# Altium schematic sheet USB.SchDoc(USB)
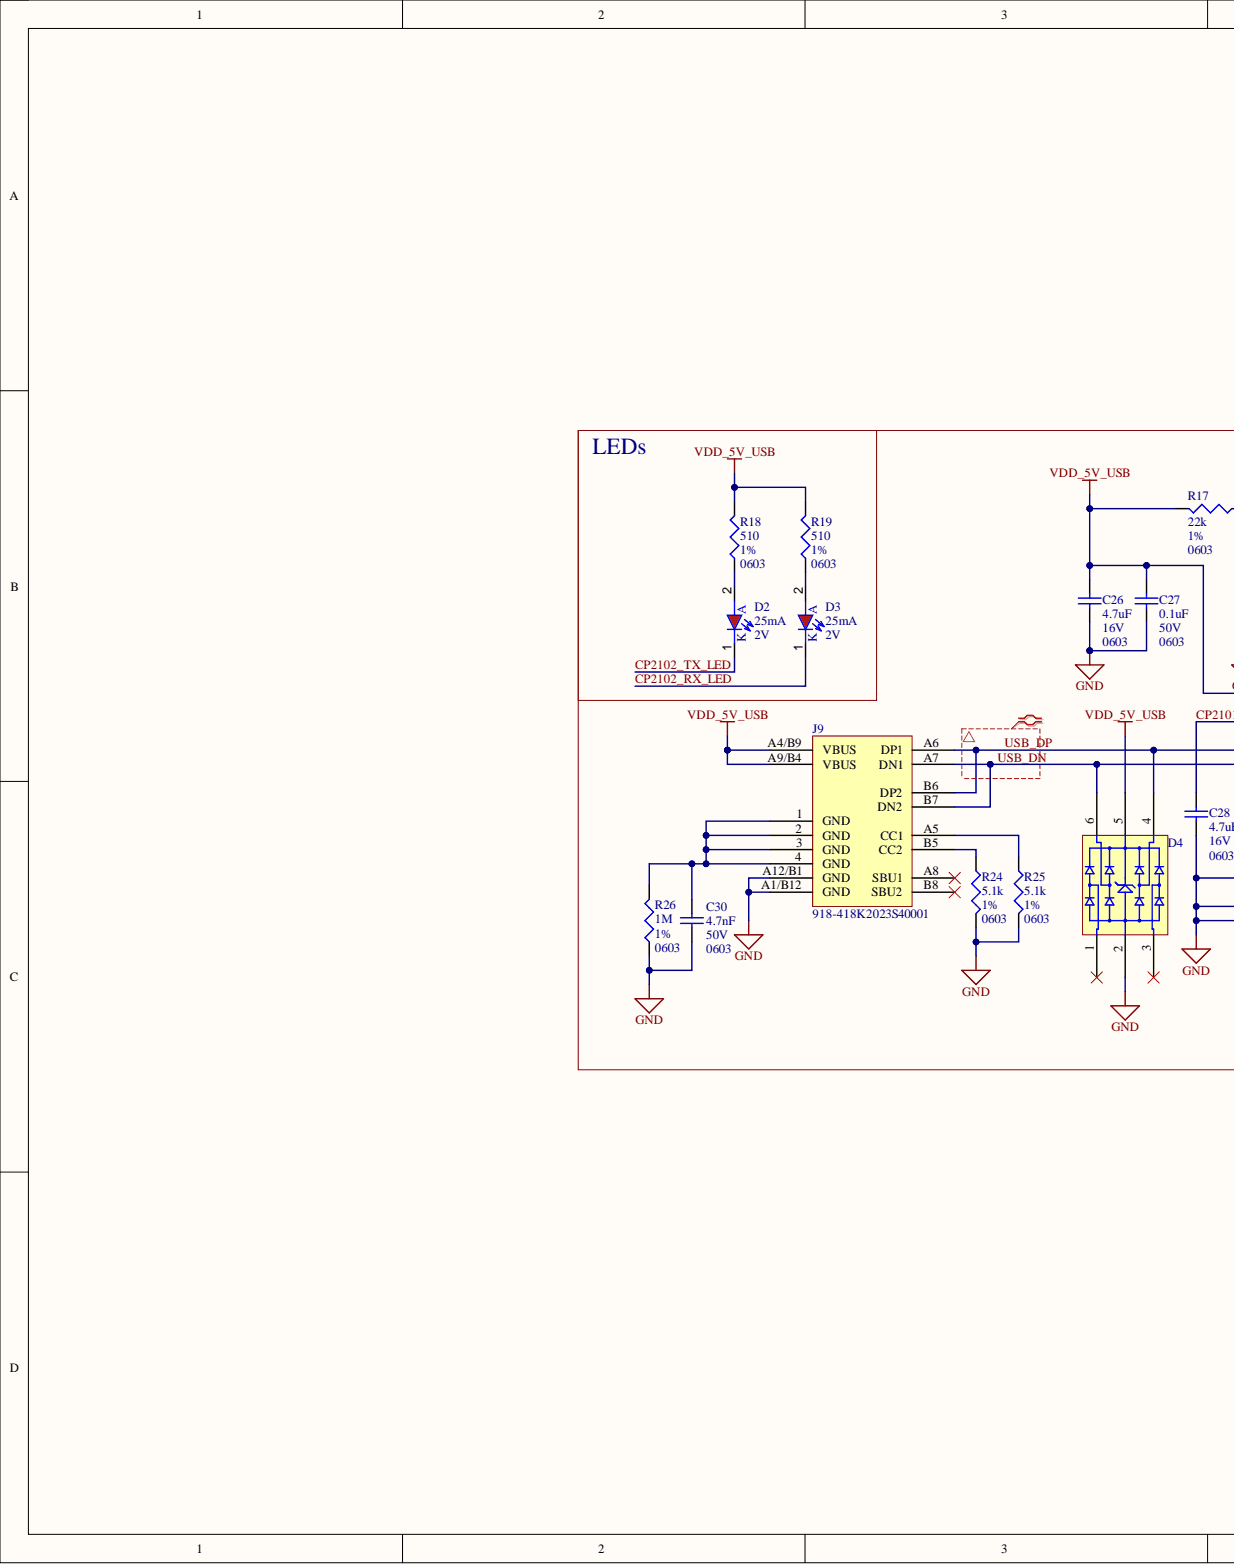
[diagram p0: USB.SchDoc, left]
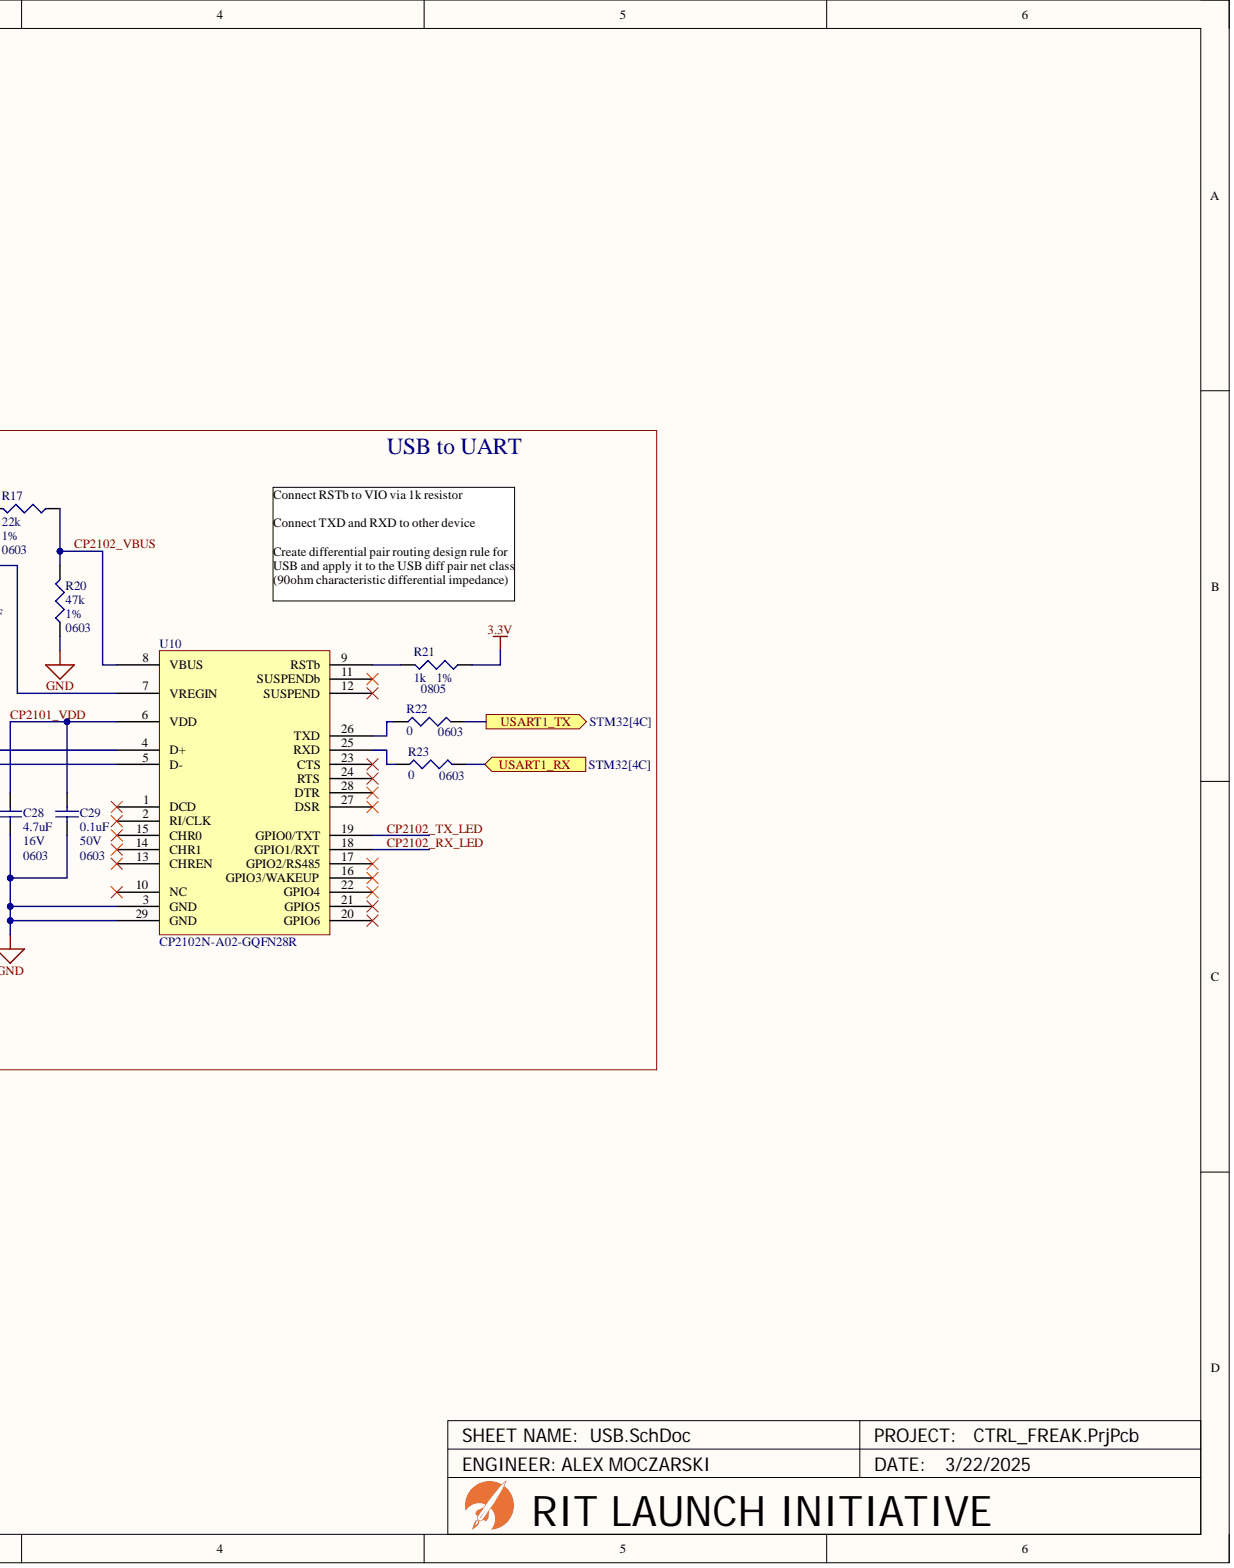
[diagram p1: USB.SchDoc, right]

## Parts
C26  Capacitance: 4.7uF  Case Code (Imperial): 0603  Voltage: 16V  (hidden comment: CL10A475KO8NNNC)
C27  Capacitance: 0.1uF  Case Code (Imperial): 0603  Voltage: 50V  (hidden comment: CC0603KRX7R9BB104)
C28  Capacitance: 4.7uF  Case Code (Imperial): 0603  Voltage: 16V  (hidden comment: CL10A475KO8NNNC)
C29  Capacitance: 0.1uF  Case Code (Imperial): 0603  Voltage: 50V  (hidden comment: CC0603KRX7R9BB104)
C30  Capacitance: 4.7nF  Case/Package: 0603  Voltage: 50V  (hidden comment: 0603B472K500NT)
D2  Forward Voltage: 2V  Max Current: 25mA  (hidden comment: NCD0805R1)
D3  Forward Voltage: 2V  Max Current: 25mA  (hidden comment: NCD0805R1)
D4  SRV05-4-P-T7  (value not drawn)
J9  918-418K2023S40001
R17  Case/Package: 0603  Resistance: 22k  Tolerance: 1%  (hidden comment: 0603WAF2202T5E)
R18  Case/Package: 0603  Tolerance: 1%  (hidden comment: 0603WAF5100T5E)
R19  Case/Package: 0603  Tolerance: 1%  (hidden comment: 0603WAF5100T5E)
R20  Case/Package: 0603  Resistance: 47k  Tolerance: 1%  (hidden comment: 0603WAF4702T5E)
R21  Resistance: 1k  Tolerance: 1%  (hidden comment: 0805W8F1001T5E)
R22  Case/Package: 0603  (hidden comment: ERJ-3GEY0R00V)
R23  Case/Package: 0603  (hidden comment: ERJ-3GEY0R00V)
R24  Case/Package: 0603  Resistance: 5.1k  Tolerance: 1%  (hidden comment: 0603WAF5101T5E)
R25  Case/Package: 0603  Resistance: 5.1k  Tolerance: 1%  (hidden comment: 0603WAF5101T5E)
R26  Case/Package: 0603  Resistance: 1M  Tolerance: 1%  (hidden comment: 0603WAF1004T5E)
U10  CP2102N-A02-GQFN28R

## Ports
USART1_RX, USART1_TX

## Nets
3.3V: R21.1
GND: C26.1 C27.1 C28.1 C29.1 C30.1 D4.2 J9.A1/B12(GND) J9.A12/B1(GND) R20.1 R24.2 R25.2 R26.1 U10.3(GND) U10.29(GND)
VDD_5V_USB: C26.2 C27.2 D4.5 J9.A4/B9(VBUS) J9.A9/B4(VBUS) R17.2 R18.2 R19.2 U10.7(VREGIN)
CP2101_VDD: C28.2 C29.2 U10.6(VDD)
CP2102_RX_LED: D3.1 U10.18(GPIO1/RXT)
CP2102_TX_LED: D2.1 U10.19(GPIO0/TXT)
CP2102_VBUS: R17.1 R20.2 U10.8(VBUS)
USART1_RX: R23.2 ; port USART1_RX
USART1_TX: R22.2 ; port USART1_TX
USB_DN: D4.6 J9.A7(DN1) J9.B7(DN2) U10.5(D-)
USB_DP: D4.4 J9.A6(DP1) J9.B6(DP2) U10.4(D+)
NetC30_2: C30.2 J9.1(GND) J9.2(GND) J9.3(GND) J9.4(GND) R26.2
NetD2_2: D2.2 R18.1
NetD3_2: D3.2 R19.1
NetJ9_A5: J9.A5(CC1) R25.1
NetJ9_B5: J9.B5(CC2) R24.1
NetR21_2: R21.2 U10.9(RSTb)
NetR22_1: R22.1 U10.26(TXD)
NetR23_1: R23.1 U10.25(RXD)

Not connected: D4 1 3; J9 A8(SBU1) B8(SBU2); U10 1(DCD) 2(RI/CLK) 10(NC) 11(SUSPENDb) 12(SUSPEND) 13(CHREN) 14(CHR1) 15(CHR0) 16(GPIO3/WAKEUP) 17(GPIO2/RS485) 20(GPIO6) 21(GPIO5) 22(GPIO4) 23(CTS) 24(RTS) 27(DSR) 28(DTR)

## Library data of the parts
C26 C28 = CMP-001-0241-2: CAP CER 4.7UF 16V X5R 0603; Material: Ceramic; Tolerance: 10%; Case Code (Metric): 1608; Case/Package: 0603; Component Kind: Standard; Construction: Flat; Contact Plating: Tin; Depth: 0.8mm; Dielectric: X5R; Height: 0.8mm; JLCPCB Part #: C19666; Length: 1.6mm; Max Operating Temperature: 85°C; Min Operating Temperature: -55°C; Mount: Surface Mount; Package Quantity: 1; Packaging: Tape and Reel; Radiation Hardening: No; RoHS Compliant: Yes; Series: CL; Thickness: 0.035inch; Voltage Rating: 16V; Width: 0.031inch; Library Name: RIT Launch Initiative
C27 C29 = CMP-001-0242-3: CAP CER 0.1UF 50V X7R 0603; Dielectric Material: Ceramic; Tolerance: 10%; Case Code (Metric): 1608; Case/Package: 0603; Component Kind: Standard; Depth: 0.8mm; Dielectric: X7R; Halogen Free: Halogen Free; Height: 0.8mm; JLCPCB Part #: C14663; Lead Free: Lead Free; Length: 0.063inch; Max Operating Temperature: 125°C; Min Operating Temperature: -55°C; Mount: Surface Mount; Number of Pins: 2; Package Quantity: 1; Packaging: Tape and Reel; Radiation Hardening: No; REACH SVHC: No SVHC; RoHS Compliant: Yes; Series: CC; Termination: SMD/SMT; Thickness: 0.035inch; Voltage Rating: 50V; Width: 0.031inch; Library Name: RIT Launch Initiative
C30 = CMP-001-0253-2: CAP CER 4.7nF 50V X7R 0603; Component Kind: Standard; JLCPCB Part #: C53987; Library Name: RIT Launch Initiative
D2 D3 = CMP-001-0246-3: LED RED CLEAR 0805 SMD; Component Kind: Standard; JLCPCB Part #: C84256; Library Name: RIT Launch Initiative
D4 = CMP-001-0239-5: SRV05 Series 4 Ch 3.5 pF 15 Vclamp Steering Diode/TVS Array Combo - SOT-23-6; Capacitance: 3.5pF; Clamping Voltage: 15V; Direction: Unidirectional; Leakage Current: 5uA; Number of Channels: 4; Number of Elements: 1; Peak Pulse Current: 5A; Peak Pulse Power: 500W; Reverse Breakdown Voltage: 6V; Reverse Standoff Voltage: 5V; Test Current: 1mA; Case/Package: SOT-23; Clamping Voltage-Max: 15V; Component Kind: Standard; Depth: 1.75mm; JLCPCB Part #: C85364; Lead Free: Lead Free; Length: 3.05mm; Max Operating Temperature: 150°C; Min Operating Temperature: -55°C; Mount: Surface Mount; Number of Pins: 6; Packaging: Tape and Reel; Polarity: Unidirectional; Radiation Hardening: No; RoHS Compliant: Yes; Working Voltage: 5V; Library Name: RIT Launch Initiative
J9 = CMP-001-0238-5: 3A 1 16 Female Type-C SMD USB Connectors ROHS; Gender: Receptacle; Mating Cycles: 10000; Number of Ports: 1; Shielding: Shielded; Component Kind: Standard; Connector Type: USB; Contact Plating: Gold; Flammability Rating: UL94 V-0; Insulation Color: Black; JLCPCB Part #: C709357; Max Operating Temperature: 85°C; Min Operating Temperature: -40°C; Mount: Surface Mount,Through Hole,Horizontal; Series: USB4105; Termination: Solder; Library Name: RIT Launch Initiative
R17 = CMP-001-0240-2: RES 22K OHM 1% 1/10W 0603; Component Kind: Standard; JLCPCB Part #: C31850; Library Name: RIT Launch Initiative
R18 R19 = CMP-001-0248-2: RES 510 OHM 1% 1/10W 0603; Resistance: 510; Component Kind: Standard; JLCPCB Part #: C23193; RoHS Compliant: Yes; Library Name: RIT Launch Initiative
R20 = CMP-001-0243-2: RES 47K OHM 1% 1/10W 0603; Component Kind: Standard; JLCPCB Part #: C25819; Library Name: RIT Launch Initiative
R21 = CMP-009-00195-1: RES SMD 1K OHM 1% 125mW 0805; Voltage: 150V; Case/Package: 0805; Component Kind: Standard; JLCPCB Part #: C17513; Library Name: RIT Launch Initiative
R22 R23 = CMP-001-0007-2: RES SMD 0 OHM JUMPER 1/10W 0603; Resistance: 0; Component Kind: Standard; JLCPCB Part #: C122704; Tolerance: --; Library Name: RIT Launch Initiative
R24 R25 = CMP-001-0244-2: RES 5.1K OHM 1% 1/10W 0603; Component Kind: Standard; JLCPCB Part #: C23186; RoHS Compliant: Yes; Library Name: RIT Launch Initiative
R26 = CMP-001-0252-2: RES 1M OHM 1% 1/10W 0603; Component Kind: Standard; JLCPCB Part #: C22935; Library Name: RIT Launch Initiative
U10 = CMP-001-0237-4: Full Speed USB to UART Bridge USB 2.0 3.3V T/R Automotive 28-Pin QFN EP; Component Kind: Standard; JLCPCB Part #: C964632; Library Name: RIT Launch Initiative
